# Altium schematic sheet Interface.SchDoc(Interface)
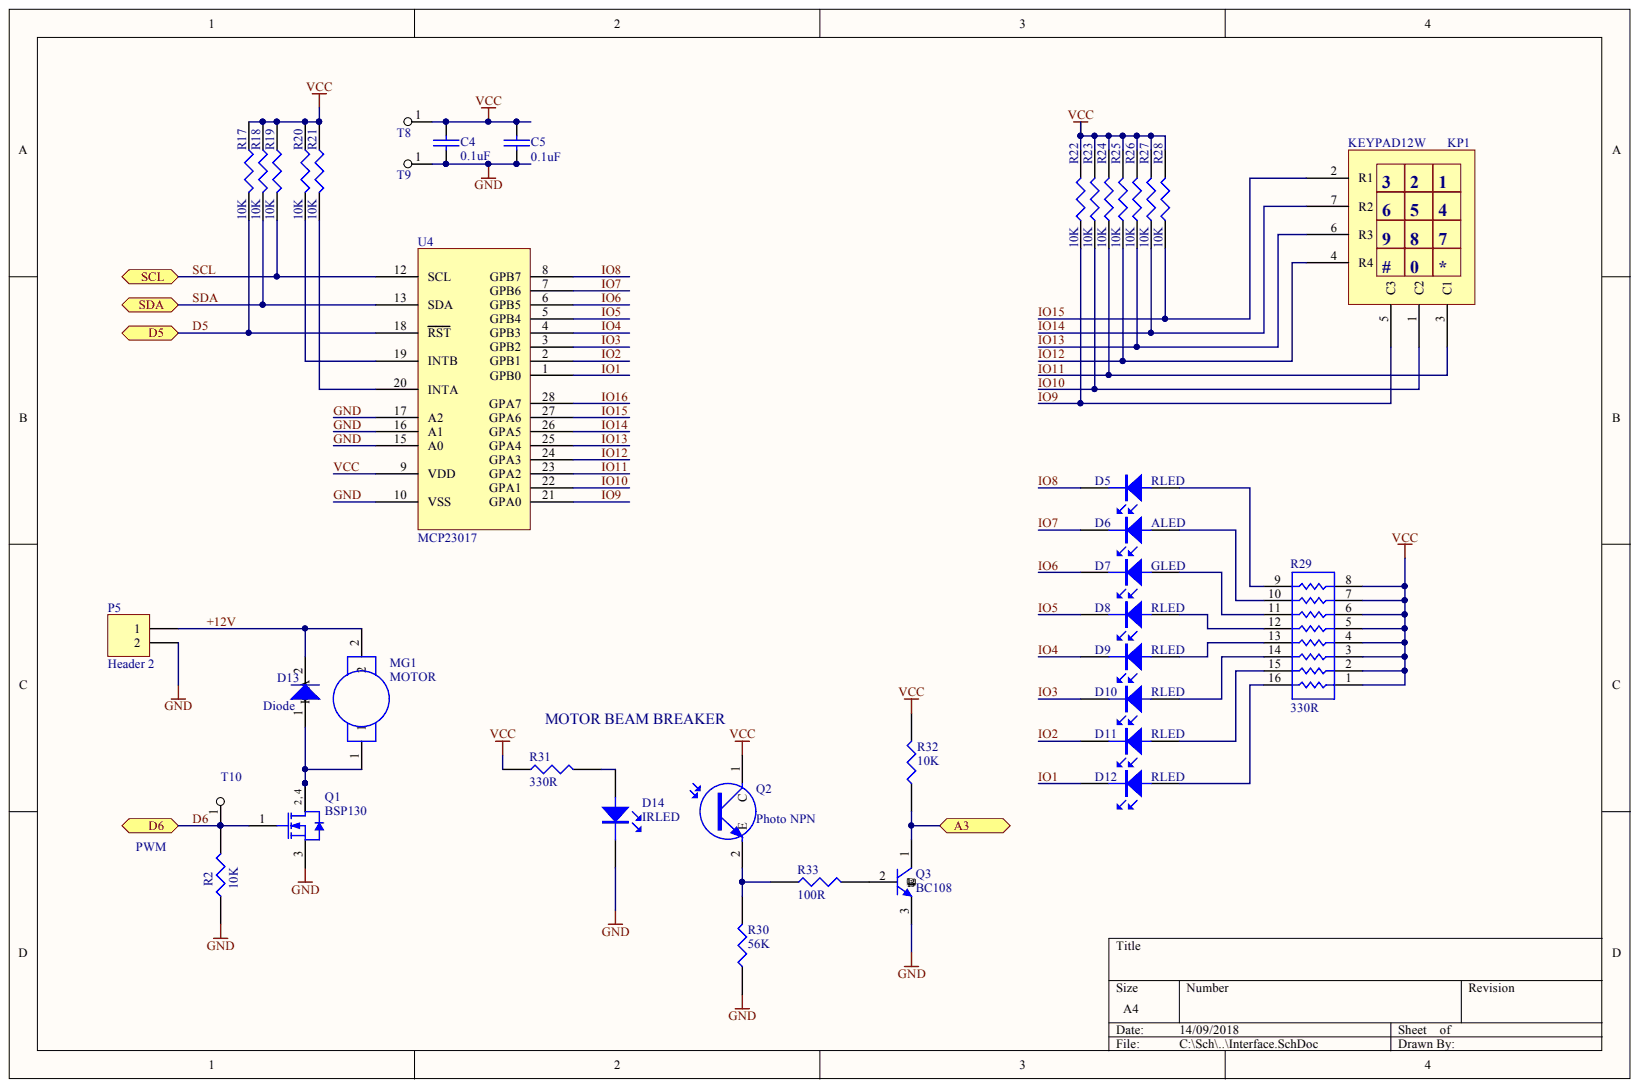
[diagram p0: Interface.SchDoc]

## Parts
C4  0.1uF  [DECAP]
C5  0.1uF  [DECAP]
D5  RLED  [LED]
D6  ALED  [LED]
D7  GLED  [LED]
D8  RLED  [LED]
D9  RLED  [LED]
D10  RLED  [LED]
D11  RLED  [LED]
D12  RLED  [LED]
D13  Diode  [IN4002]
D14  IRLED  [LED]
KP1  KEYPAD12W  [KEYPAD3X4]
MG1  MOTOR  [MOTOR]
P5  Header 2  [2PINTERM]
Q1  BSP130  [SOT223_N]
Q2  Photo NPN  [LED]
Q3  BC108  [BC108]
R2  10K  [RES0.4]
R17  10K  [RES0.4]
R18  10K  [RES0.4]
R19  10K  [RES0.4]
R20  10K  [RES0.4]
R21  10K  [RES0.4]
R22  10K  [RES0.4]
R23  10K  [RES0.4]
R24  10K  [RES0.4]
R25  10K  [RES0.4]
R26  10K  [RES0.4]
R27  10K  [RES0.4]
R28  10K  [RES0.4]
R29  330R  [DIP16]
R30  56K  [RES0.4]
R31  330R  [RES0.4]
R32  10K  [RES0.4]
R33  100R  [RES0.4]
T8  TP  [TP]  (value not drawn)
T9  TP  [TP]  (value not drawn)
T10  TP  [TP]  (value not drawn)
U4  MCP23017  [SPDIP300-SP28]

## Ports
A3, D5, D6, SCL, SDA

## Nets
+12V: D13.2 MG1.2 P5.1
A3: Q3.1 R32.1 ; port A3
D5: R17.2 U4.18(RST) ; port D5
D6: Q1.1 R2.2 T10.1 ; port D6
GND: C4.2 C5.2 D14.1 P5.2 Q1.3 Q3.3 R2.1 R30.1 T9.1 U4.10(VSS) U4.15(A0) U4.16(A1) U4.17(A2)
IO1: D12.1 U4.1(GPB0)
IO2: D11.1 U4.2(GPB1)
IO3: D10.1 U4.3(GPB2)
IO4: D9.1 U4.4(GPB3)
IO5: D8.1 U4.5(GPB4)
IO6: D7.1 U4.6(GPB5)
IO7: D6.1 U4.7(GPB6)
IO8: D5.1 U4.8(GPB7)
IO9: KP1.5(C3) R22.1 U4.21(GPA0)
IO10: KP1.1(C2) R23.1 U4.22(GPA1)
IO11: KP1.3(C1) R24.1 U4.23(GPA2)
IO12: KP1.4(R4) R25.1 U4.24(GPA3)
IO13: KP1.6(R3) R26.1 U4.25(GPA4)
IO14: KP1.7(R2) R27.1 U4.26(GPA5)
IO15: KP1.2(R1) R28.1 U4.27(GPA6)
IO16: U4.28(GPA7)
SCL: R19.2 U4.12(SCL) ; port SCL
SDA: R18.2 U4.13(SDA) ; port SDA
VCC: C4.1 C5.1 Q2.1 R17.1 R18.1 R19.1 R20.1 R21.1 R22.2 R23.2 R24.2 R25.2 R26.2 R27.2 R28.2 R29.1 R29.2 R29.3 R29.4 R29.5 R29.6 R29.7 R29.8 R31.1 R32.2 T8.1 U4.9(VDD)
NetD5_2: D5.2 R29.9
NetD6_2: D6.2 R29.10
NetD7_2: D7.2 R29.11
NetD8_2: D8.2 R29.12
NetD9_2: D9.2 R29.13
NetD10_2: D10.2 R29.14
NetD11_2: D11.2 R29.15
NetD12_2: D12.2 R29.16
NetD13_1: D13.1 MG1.1 Q1.2 Q1.4
NetD14_2: D14.2 R31.2
NetQ2_2: Q2.2 R30.2 R33.1
NetQ3_2: Q3.2 R33.2
NetR20_2: R20.2 U4.19(INTB)
NetR21_2: R21.2 U4.20(INTA)

## Library data of the parts
C4 C5 = CAP: Component Kind: Standard; Library Name: Ethernet.SchLib
D5-D12 = LED: Component Kind: Standard
D13 = Diode: Default Diode; Code_JEDEC: DO-214-AB; Component Kind: Standard; LatestRevisionDate: 17-Jul-2002; LatestRevisionNote: Re-released for DXP Platform.; Library Name: Miscellaneous Devices.IntLib; PackageDescription: DO-214-AB/SMC; 2 C-Bend Leads; Body 7.9 x 5.9 mm, inc. leads (LxW); PackageReference: SMC; PackageVersion: Sep-1996; Published: 8-Jun-2000; Signal Integrity: Diode; Simulation: DIODE; Publisher: Altium Limited
D14 = LED: F1612496; Component Kind: Standard
KP1 = KEYPAD12W: KEYPAD 12 BUTTON; Component Kind: Standard
MG1 = MOTOR AC: Component Kind: Standard
P5 = Header 2: Header, 2-Pin; Component Kind: Standard; LatestRevisionDate: 17-Jul-2002; LatestRevisionNote: Re-released for DXP Platform.; Library Name: Miscellaneous Connectors.IntLib; Signal Integrity: Connector; Publisher: Altium Limited
Q1 = BSP130: N-channel Enhancement Mode Vertical D-MOSFET; Component Kind: Standard; ComponentLink1Description: Manufacturer Link; ComponentLink1URL: http://www.semiconductors.philips.com/; ComponentLink2Description: Datasheet; ComponentLink2URL: http://www.semiconductors.philips.com/acrobat/datasheets/BSP130_3.pdf; DatasheetVersion: 11-Dec-2001; LatestRevisionDate: 12-Dec-2006; LatestRevisionNote: IPC-7351 Footprint Added.; PackageDescription: 4-Pin SOT-223 Package 2.3 mm Pitch, 7 mm Lead Span; PackageReference: SOT223; PackageVersion: Aug-2001; Published: 17-Sep-2003; Simulation: BSP130; Library Name: DSPIC_Board_DMU.SCHLIB; Publisher: Altium Limited
Q2 = Photo NPN: F1328399; Code_JEDEC: TO-220-AC; Component Kind: Standard; LatestRevisionDate: 17-Jul-2002; LatestRevisionNote: Re-released for DXP Platform.; Library Name: Miscellaneous Devices.IntLib; PackageReference: TO-220_A; Published: 24-Mar-1999; Publisher: Altium Limited
Q3 = NPN: NPN Bipolar Transistor; Code_JEDEC: TO-226-AA; Component Kind: Standard; LatestRevisionDate: 17-Jul-2002; LatestRevisionNote: Re-released for DXP Platform.; Library Name: Miscellaneous Devices.IntLib; PackageDescription: TO, Flat Index; 3 In-Line, Axial Leads; Body Dia. 4.8mm; Leads 0.55 x 0.51 mm (max); PackageReference: TO-226-AA; Published: 8-Jun-2000; Signal Integrity: NPN; Simulation: NPN; Publisher: Altium Limited
R2 R17-R28 R30-R33 = RES1: Component Kind: Standard; Library Name: Ethernet.SchLib
R29 = Res Pack3: Resistor Network; Component Kind: Standard; LatestRevisionDate: 17-Jul-2002; LatestRevisionNote: Re-released for DXP Platform.; Library Name: Miscellaneous Devices.IntLib; PackageReference: SO-16; Published: 24-Mar-1999; Simulation: respack_8; Value: 330R; Publisher: Altium Limited
U4 = MCP23017: F1332087; Component Kind: Standard; Library Name: PIC24FJ64GB004_1.SCHLIB
T8-T10 = TP: Component Kind: Standard; Library Name: Student.SchLib
